# Altium schematic sheet ESP.SchDoc
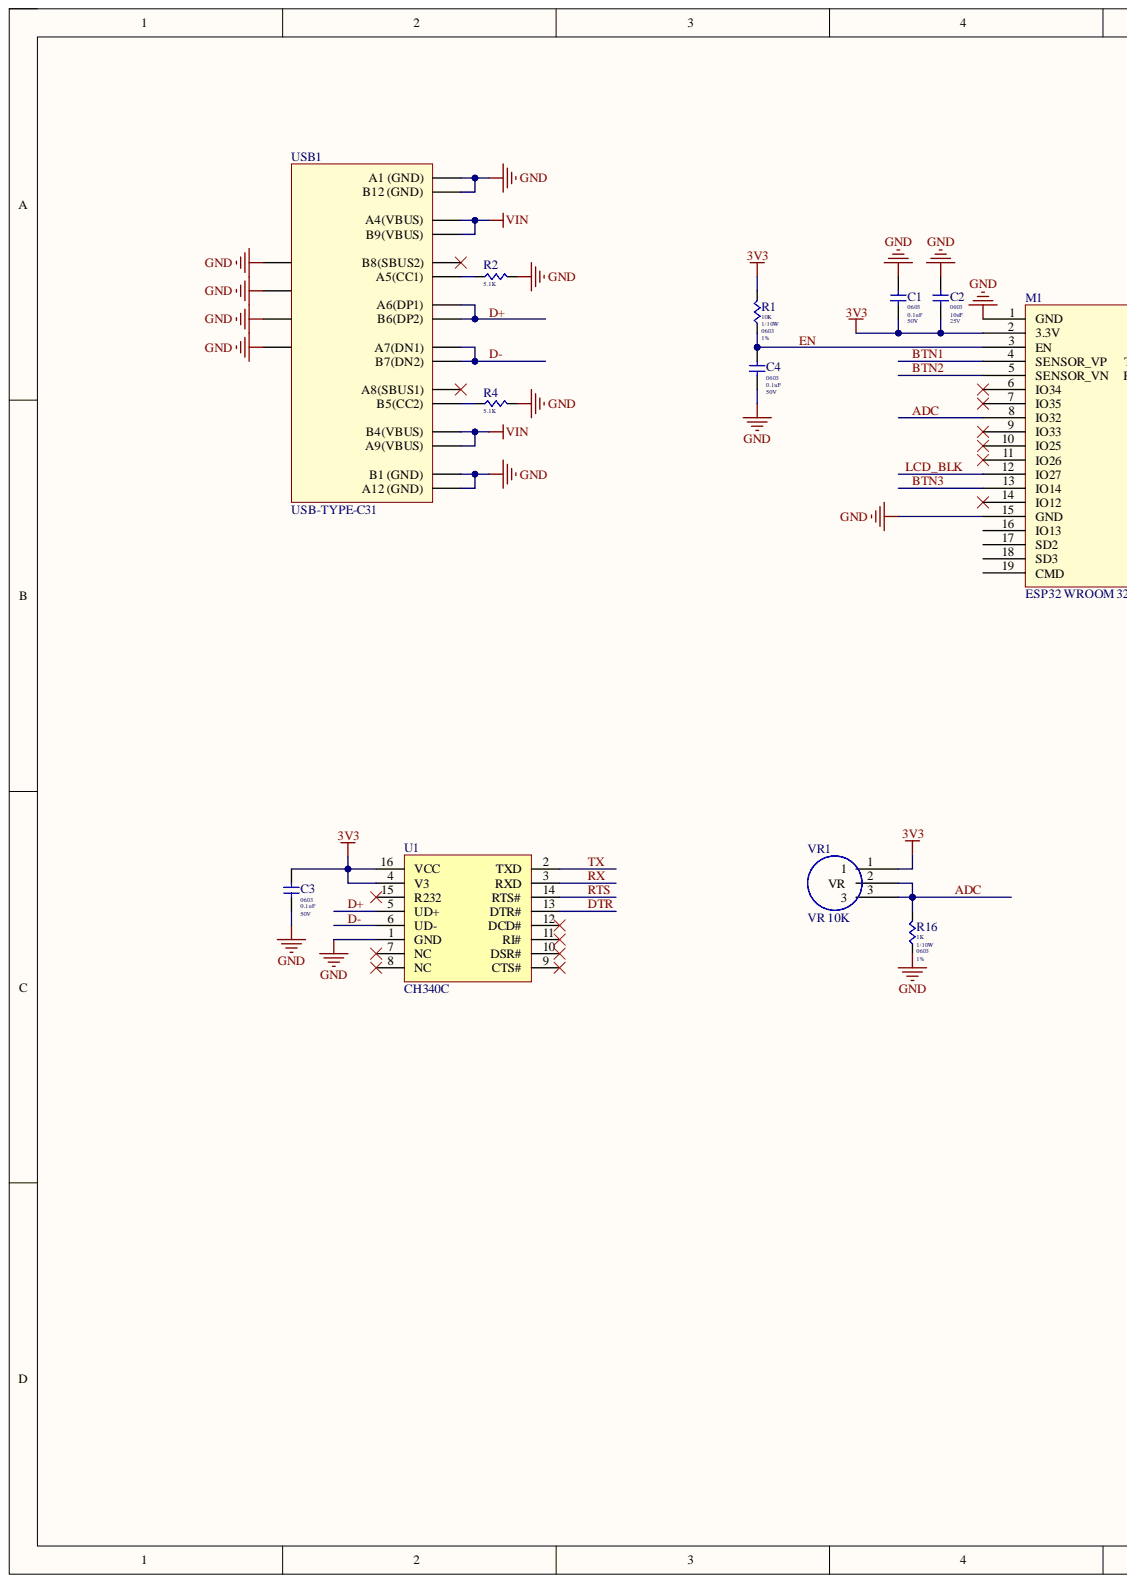
[diagram p0: ESP.SchDoc, left]
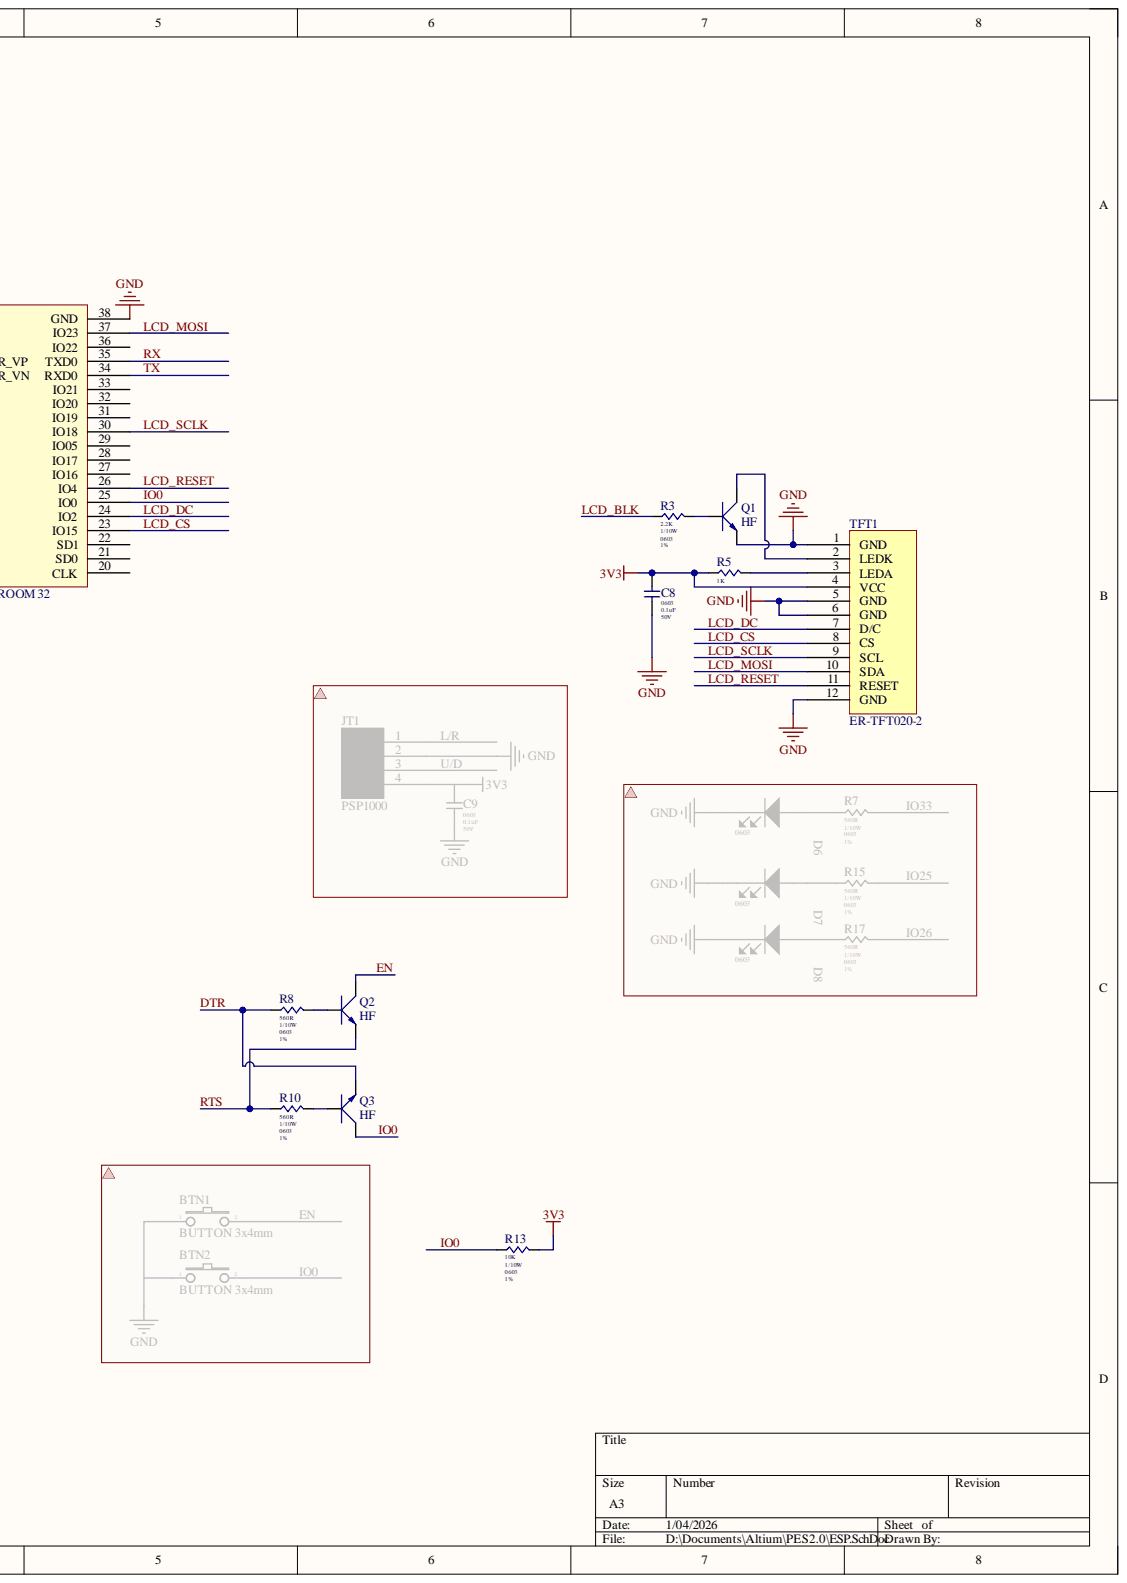
[diagram p1: ESP.SchDoc, right]

## Parts
C1  value 0.1uF  Package: 0603  Voltage: 50V  [Cap_0603]  (hidden comment: CL10B104KB8NNNC)
C2  value 10uF  Package: 0603  Voltage: 25V  [Cap_0603]  (hidden comment: CL10A106MA8NRNC)
C3  value 0.1uF  Package: 0603  Voltage: 50V  [Cap_0603]  (hidden comment: CL10B104KB8NNNC)
C4  value 0.1uF  Package: 0603  Voltage: 50V  [Cap_0603]  (hidden comment: CL10B104KB8NNNC)
C8  value 0.1uF  Package: 0603  Voltage: 50V  [Cap_0603]  (hidden comment: CL10B104KB8NNNC)
M1  ESP32 WROOM 32  [ESP32-WROOM]
Q1  HF  [SOT23]
Q2  HF  [SOT23]
Q3  HF  [SOT23]
R1  value 10K  Package: 0603  Power: 1/10W  Tolerance: 1%  [RESC1608X05N]  (hidden comment: RC0603FR-0710KL)
R2  value 5.1K  [RESC1608X05N]  (hidden comment: RMCF0603FT5K10)
R3  value 2.2K  Pakage: 0603  Power: 1/10W  Tolerance: 1%  [RESC1608X05N]  (hidden comment: RMCF0603FT2K20)
R4  value 5.1K  [RESC1608X05N]  (hidden comment: RMCF0603FT5K10)
R5  value 1K  [RESC1608X05N]  (hidden comment: RC0603FR-071KL)
R8  value 560R  Package: 0603  Power: 1/10W  Tolerance: 1%  [RESC1608X05N]  (hidden comment: RC0603FR-07560RL)
R10  value 560R  Package: 0603  Power: 1/10W  Tolerance: 1%  [RESC1608X05N]  (hidden comment: RC0603FR-07560RL)
R13  value 10K  Package: 0603  Power: 1/10W  Tolerance: 1%  [RESC1608X05N]  (hidden comment: RC0603FR-0710KL)
R16  value 1K  Pakage: 0603  Power: 1/10W  Tolerance: 1%  [RESC1608X05N]  (hidden comment: RC0603FR-071KL)
TFT1  ER-TFT020-2  [TFT-2inches]
U1  CH340C  [SOIC16_50_284_25X90_SMT]
USB1  USB-TYPE-C31  [TYPE-C-31-M-12]
VR1  VR 10K  [VR_10K]

## Nets
3V3: C1.2 C2.2 C3.2 C8.2 M1.2(3.3V) R1.2 R5.1 R13.2 TFT1.4(VCC) U1.4(V3) U1.16(VCC) VR1.1
GND: C1.1 C2.1 C3.1 C4.1 C8.1 M1.1(GND) M1.15(GND) M1.38(GND) Q1.2 R2.2 R4.2 R16.1 TFT1.1(GND) TFT1.5(GND) TFT1.6(GND) TFT1.12(GND) U1.1(GND) USB1.0 USB1.A1(A1_(GND)) USB1.A12(A12_(GND)) USB1.B1(B1_(GND)) USB1.B12(B12_(GND))
VIN: USB1.A4(A4(VBUS)) USB1.A9(A9(VBUS)) USB1.B4(B4(VBUS)) USB1.B9(B9(VBUS))
ADC: M1.8(IO32) R16.2 VR1.2 VR1.3
BTN1: M1.4(SENSOR_VP)
BTN2: M1.5(SENSOR_VN)
BTN3: M1.13(IO14)
D+: U1.5(UD+) USB1.A6(A6(DP1)) USB1.B6(B6(DP2))
D-: U1.6(UD-) USB1.A7(A7(DN1)) USB1.B7(B7(DN2))
DTR: Q3.2 R8.1 U1.13(DTR#)
EN: C4.2 M1.3(EN) Q2.3 R1.1
IO0: M1.25(IO0) Q3.3 R13.1
LCD_BLK: M1.12(IO27) R3.1
LCD_CS: M1.23(IO15) TFT1.8(CS)
LCD_DC: M1.24(IO2) TFT1.7(D/C)
LCD_MOSI: M1.37(IO23) TFT1.10(SDA)
LCD_RESET: M1.26(IO4) TFT1.11(RESET)
LCD_SCLK: M1.30(IO18) TFT1.9(SCL)
RTS: Q2.2 R10.1 U1.14(RTS#)
RX: M1.35(TXD0) U1.3(RXD)
TX: M1.34(RXD0) U1.2(TXD)
NetQ1_1: Q1.1 R3.2
NetQ1_3: Q1.3 TFT1.2(LEDK)
NetQ2_1: Q2.1 R8.2
NetQ3_1: Q3.1 R10.2
NetR2_1: R2.1 USB1.A5(A5(CC1))
NetR4_1: R4.1 USB1.B5(B5(CC2))
NetR5_2: R5.2 TFT1.3(LEDA)

Not connected: M1 6(IO34) 7(IO35) 9(IO33) 10(IO25) 11(IO26) 14(IO12) 16(IO13) 17(SD2) 18(SD3) 19(CMD) 20(CLK) 21(SD0) 22(SD1) 27(IO16) 28(IO17) 29(IO05) 31(IO19) 32(IO20) 33(IO21) 36(IO22); U1 7(NC) 8(NC) 9(CTS#) 10(DSR#) 11(RI#) 12(DCD#) 15(R232); USB1 A8(A8(SBUS1)) B8(B8(SBUS2))

## Library data of the parts
C1 C3 C4 C8 = Cap Cer_0.1uF_50V_X7R_0603: CAP CER 0.1UF 50V X7R 0603; Component Kind: Standard; ComponentLink1Description: CXT; ComponentLink1URL: https://linhkien.cxtvn.com/1981-tu-0603-khong-phan-cuc.html; ComponentLink2Description: DIGIKEY; ComponentLink2URL: https://www.digikey.com/en/products/detail/samsung-electro-mechanics/CL10B104KB8NNNC/3886658; Library Name: HungKings.IntLib
C2 = Cap Cer_10uF_25V_X5R_0603: CAP CER 10UF 25V X5R 0603; Component Kind: Standard; ComponentLink1Description: CXT; ComponentLink1URL: https://linhkien.cxtvn.com/2886-tu-0603-khong-phan-cuc.html; ComponentLink2Description: DIGIKEY; ComponentLink2URL: https://www.digikey.com/en/products/detail/samsung-electro-mechanics/CL10A106MA8NRNC/3887527; Library Name: HungKings.IntLib
M1 = Module_ESP32 WROOM 32: Component Kind: Standard; Library Name: HungKings.IntLib
Q1-Q3 = C1815 HF SMD: NPN transistor; Value: C9014; Component Kind: Standard; Library Name: Altium_Lib_by_KhueNguyenCreator.IntLib
R1 R13 = Res_10K_0603_1%_1/10W: RES 10K OHM 1% 1/10W 0603; Component Kind: Standard; ComponentLink1Description: CXT; ComponentLink1URL: https://linhkien.cxtvn.com/1422-dien-tro-0603-1-thong-so-tu-1k-den-91k.html; ComponentLink2Description: DIGIKEY; ComponentLink2URL: https://www.digikey.com/en/products/detail/yageo/RC0603FR-0710KL/726880?s=N4IgTCBcDaIEoGEAMA2JBmAYnAtEg7AIxIDSAMiALoC%2BQA; Library Name: HungKings.IntLib
R2 R4 = Res_5.1K_0603_1%_1/10W: RES 5.1K OHM 1% 1/10W 0603; Pakage: 0603; Component Kind: Standard; ComponentLink1Description: CXT; ComponentLink1URL: https://linhkien.cxtvn.com/2291-dien-tro-0603-1-thong-so-tu-1k-den-91k.html; ComponentLink2Description: DIGIKEY; ComponentLink2URL: https://www.digikey.bg/en/products/detail/stackpole-electronics-inc/RMCF0603FT5K10/1760908?s=N4IgTCBcDaIEoFkDCAxADANjQZhQFQFYBpARjRAF0BfIA; Power: 1/10W; Tolerance: 1%; Library Name: HungKings.IntLib
R3 = Res_2.2K_0603_1%_1/10W: RES 2.2K OHM 1% 1/10W 0603; Component Kind: Standard; ComponentLink1Description: CXT; ComponentLink1URL: https://linhkien.cxtvn.com/3649-dien-tro-0603-1-thong-so-tu-1k-den-91k.html; ComponentLink2Description: DIGIKEY; ComponentLink2URL: https://www.digikey.com/en/products/detail/stackpole-electronics-inc/RMCF0603FT2K20/1760987; Library Name: HungKings.IntLib
R5 = Res_1K_0603_1%_1/10W: RES 1K OHM 1% 1/10W 0603; Pakage: 0603; Component Kind: Standard; ComponentLink1Description: New link; ComponentLink1URL: https://linhkien.cxtvn.com/1424-dien-tro-0603-1-thong-so-tu-1k-den-91k.html; ComponentLink2Description: New link 1; ComponentLink2URL: https://www.digikey.bg/en/products/detail/yageo/RC0603FR-071KL/726843; Power: 1/10W; Tolerance: 1%; Library Name: HungKings.IntLib
R8 R10 = Res_560R_0603_1%_1/10W: RES 560 OHM 1% 1/10W 0603; Component Kind: Standard; ComponentLink1Description: CXT; ComponentLink1URL: https://linhkien.cxtvn.com/6651-dien-tro-0603-1-thong-so-tu-0r-den-910r.html; ComponentLink2Description: DIGIKEY; ComponentLink2URL: https://www.digikey.bg/en/products/detail/yageo/RC0603FR-07560RL/727304; Library Name: HungKings.IntLib
R16 = Res_1K_0603_1%_1/10W: RES 1K OHM 1% 1/10W 0603; Component Kind: Standard; ComponentLink1Description: New link; ComponentLink1URL: https://linhkien.cxtvn.com/1424-dien-tro-0603-1-thong-so-tu-1k-den-91k.html; ComponentLink2Description: New link 1; ComponentLink2URL: https://www.digikey.bg/en/products/detail/yageo/RC0603FR-071KL/726843; Library Name: HungKings.IntLib
TFT1 = TFT_2inch: TFT LCD Display 2.0inches; Component Kind: Standard; ComponentLink1Description: Shoppe; ComponentLink1URL: https://shopee.vn/product/1362727719/43415097966?d_id=24802&uls_trackid=53rr0i1h01r3&utm_content=3qg4xqPmq1JgqbeSYSVKJPMds6jy; Library Name: HungKings.IntLib
U1 = CH340C: USB Bridge, USB to UART USB 2.0 UART Interface; Alternate Part Number-1: NA; Manufacturer: Olimex; Case/Package: SOIC16; Component Kind: Standard; Manufacturer Part Number: CH340C; Supplier Part Number-1: C84681; Supplier-1: LCSC; Library Name: Altium_Lib_by_KhueNguyenCreator.IntLib
USB1 = USB-TYPE-C: USB connector; Component Kind: Standard; Value: USB; Library Name: HungKings.IntLib
VR1 = VR_10K: Component Kind: Standard; ComponentLink1Description: LKCL; ComponentLink1URL: https://linhkienchatluong.vn/chiet-ap-rv12mm-tron/bien-tro-tron-don-10k-16x2mm-3-chan_sp1171_ct8728.aspx; Library Name: HungKings.IntLib
